# Altium schematic sheet TOP.SchDoc(TOP)
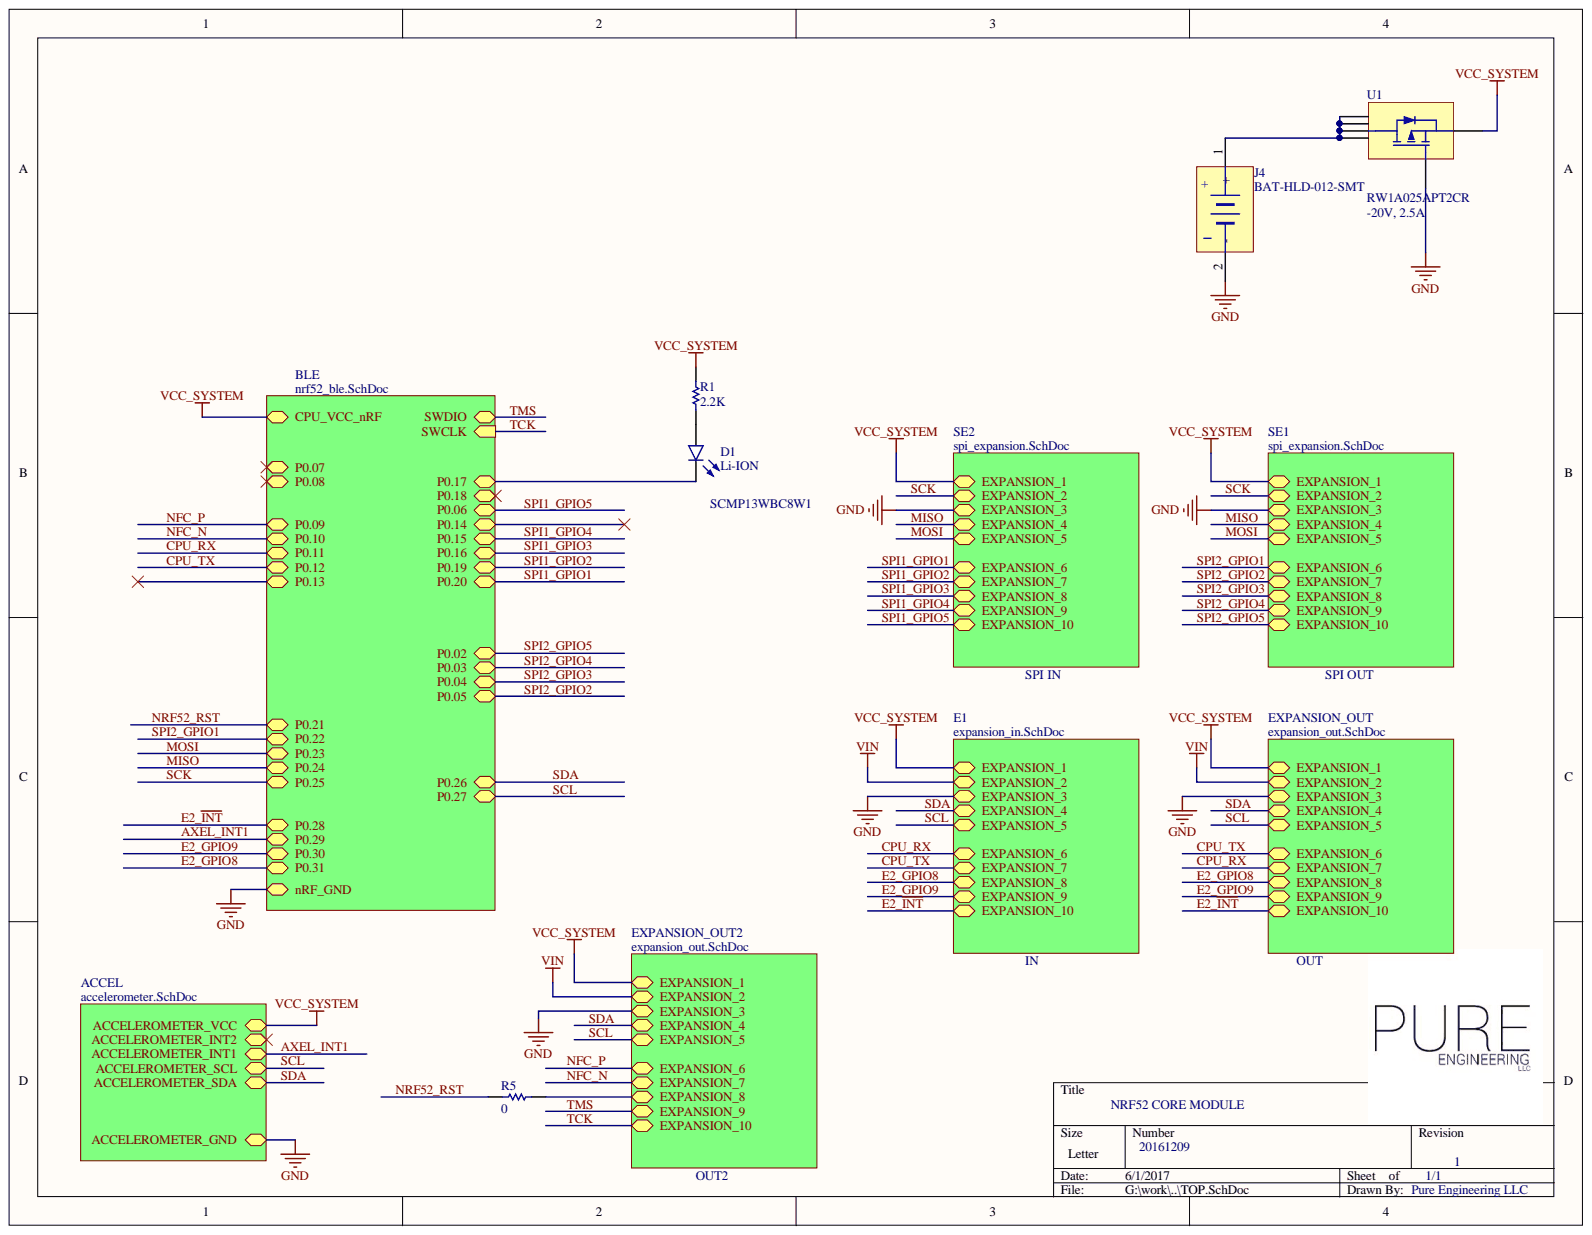
[diagram p0: TOP.SchDoc]

## Parts
D1  Li-ION  MANUFACTURER P/N: SCMP13WBC8W1  [SMLP13WBC8W1]
J4  BAT-HLD-012-SMT  [BAT-HLD-012-SMT-MINI]
R1  value 2.2K  [0402 RESC1005X40N]  (hidden comment: ERJ-2RKF2201X)
R5  value 0  [0402 RESC1005X40N]  (hidden comment: ERJ-2GE0R00X)
U1  value -20V, 2.5A  MANUFACTURER P/N: RW1A025APT2CR  [SOT-666]  (hidden comment: RW1C025ZPT2CR)

## Nets
GND: J4.2 U1.3
VCC_SYSTEM: R1.1 U1.4
NRF52_RST: R5.2
NetD1_1: D1.1 R1.2
NetD1_2: D1.2
NetJ4_1: J4.1 U1.1 U1.2 U1.5 U1.6
NetR5_1: R5.1
ACCELEROMETER_INT2:
AXEL_INT1:
CPU_RX:
CPU_TX:
E2_GPIO8:
E2_GPIO9:
E2_~{INT}:
MISO:
MOSI:
NFC_N:
NFC_P:
P0.07:
P0.08:
P0.13:
P0.14:
P0.18:
SCK:
SCL:
SDA:
SPI1_GPIO1:
SPI1_GPIO2:
SPI1_GPIO3:
SPI1_GPIO4:
SPI1_GPIO5:
SPI2_GPIO1:
SPI2_GPIO2:
SPI2_GPIO3:
SPI2_GPIO4:
SPI2_GPIO5:
TCK:
TMS:
VIN:

## Library data of the parts
D1 = SMLP13WBC8W1: LED COOL WHITE 5MA 1mm x .6mm x .2mm; MANUFACTURER: Rohm Semiconductor; VALIDATED BY: NV; Component Kind: Standard; COST: .68; DISTRIBUTOR: Digikey; DISTRIBUTOR P/N: 846-1111-1-ND; Library Name: SchematicLibrary.SchLib; VALUE: White; CREATED BY: SO
J4 = BAT-HLD-012-SMT: RETAINER BATT CR1216/1225 SMD; MANUFACTURER: Linx Technologies Inc.; VALIDATED BY: NV; Component Kind: Standard; COST: .28; DISTRIBUTOR: DIGIKEY; DISTRIBUTOR P/N: BAT-HLD-012-SMT-ND; Library Name: KL_SchematicLibrary.SchLib; MANUFACTURER P/N: BAT-HLD-012-SMT; CREATED BY: KL
R1 = ERJ-2RKF2201X: Resistor, 2.2K Ohm, 1/16W 1% 0402 SMD; MANUFACTURER: PANASONIC; Component Kind: Standard; COST: 0.098; DISTRIBUTOR: DIGI-KEY; DISTRIBUTOR P/N: P2.20KLCT-ND; Library Name: PassiveSchematicLibrary.SchLib; MANUFACTURER P/N: ERJ-2RKF2201X; CREATED BY: SO
R5 = ERJ-2GE0R00X: Resistor, 0 Ohm, 1/16W 0402 SMD; MANUFACTURER: PANASONIC; Component Kind: Standard; COST: 0.098; DISTRIBUTOR: DIGI-KEY; DISTRIBUTOR P/N: P0.0JCT-ND; Library Name: SO_PassiveSchematicLibrary.SchLib; MANUFACTURER P/N: ERJ-2GE0R00X; CREATED BY: SO
U1 = RW1C025ZPT2CR: MOSFET P-CH 20V 2.5A WEMT6; MANUFACTURER: Rohm Semiconductor; VALIDATED: NV; Component Kind: Standard; COST: .42; DISTRIBUTOR: DIGI-KEY; DISTRIBUTOR P/N: RW1C025ZPT2CRCT-ND; Library Name: SO_SchematicLibrary.SchLib; CREATED BY: SO DEC 12
